# Altium schematic sheet Main.SchDoc
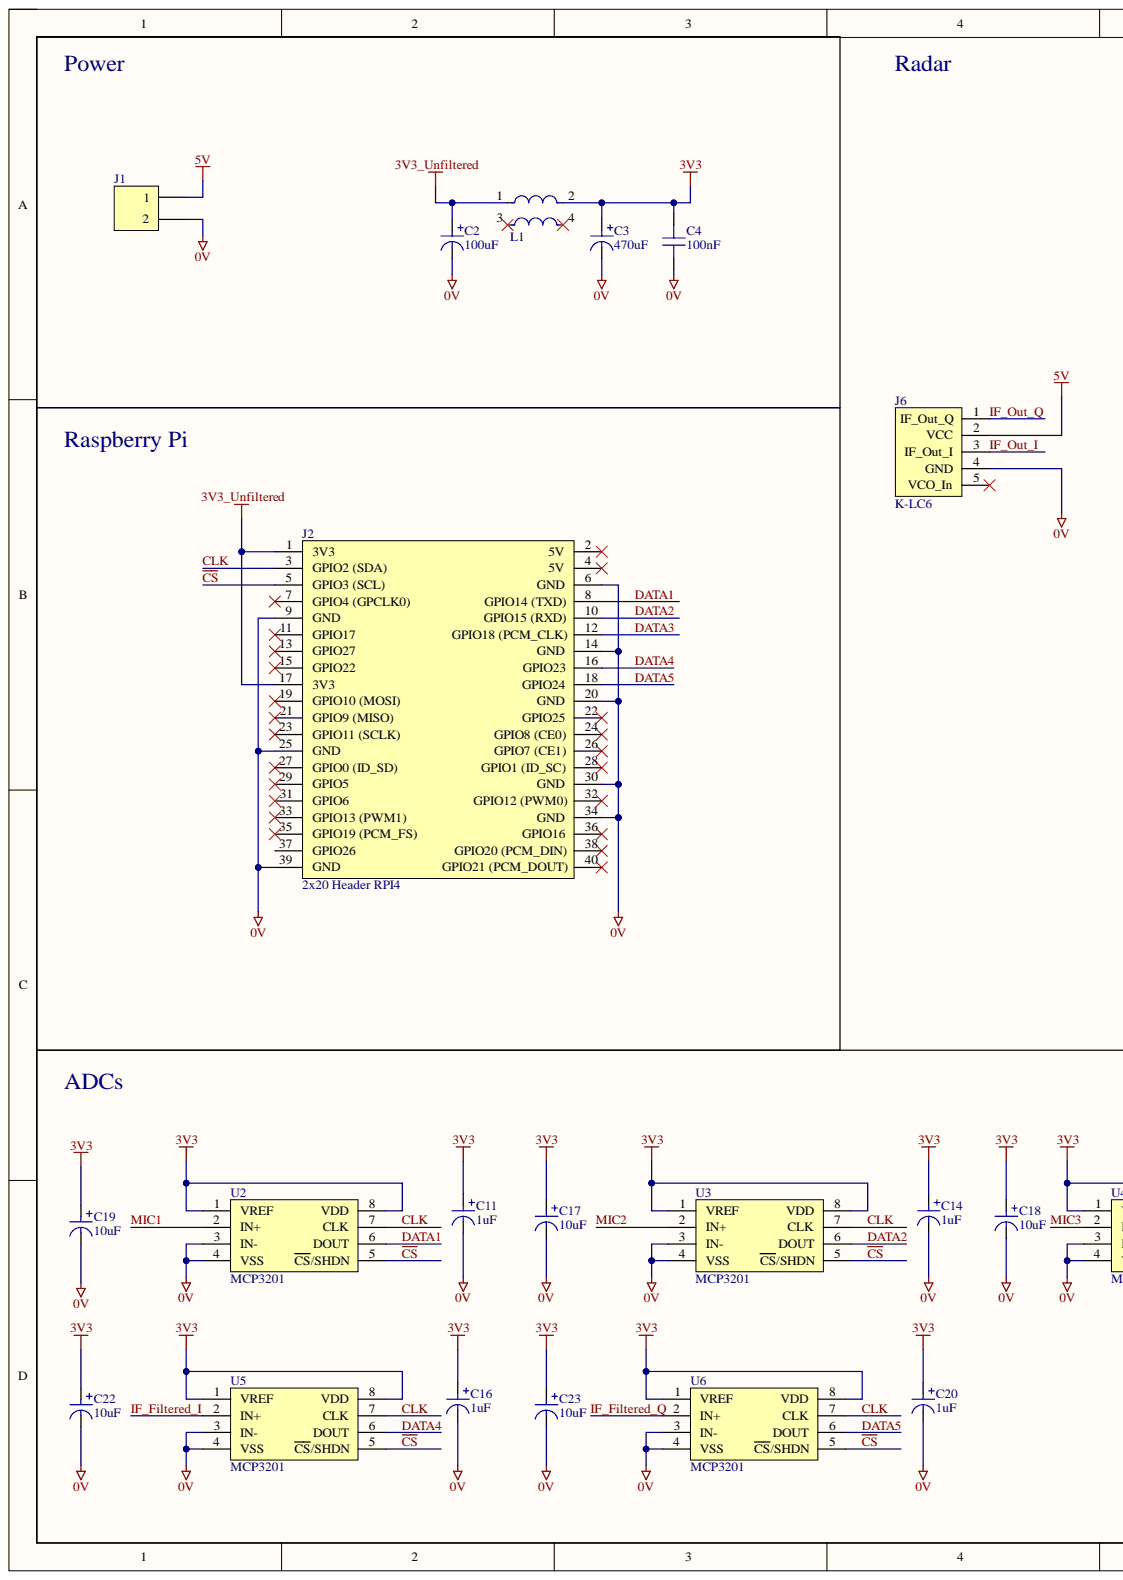
[diagram p0: Main.SchDoc, left]
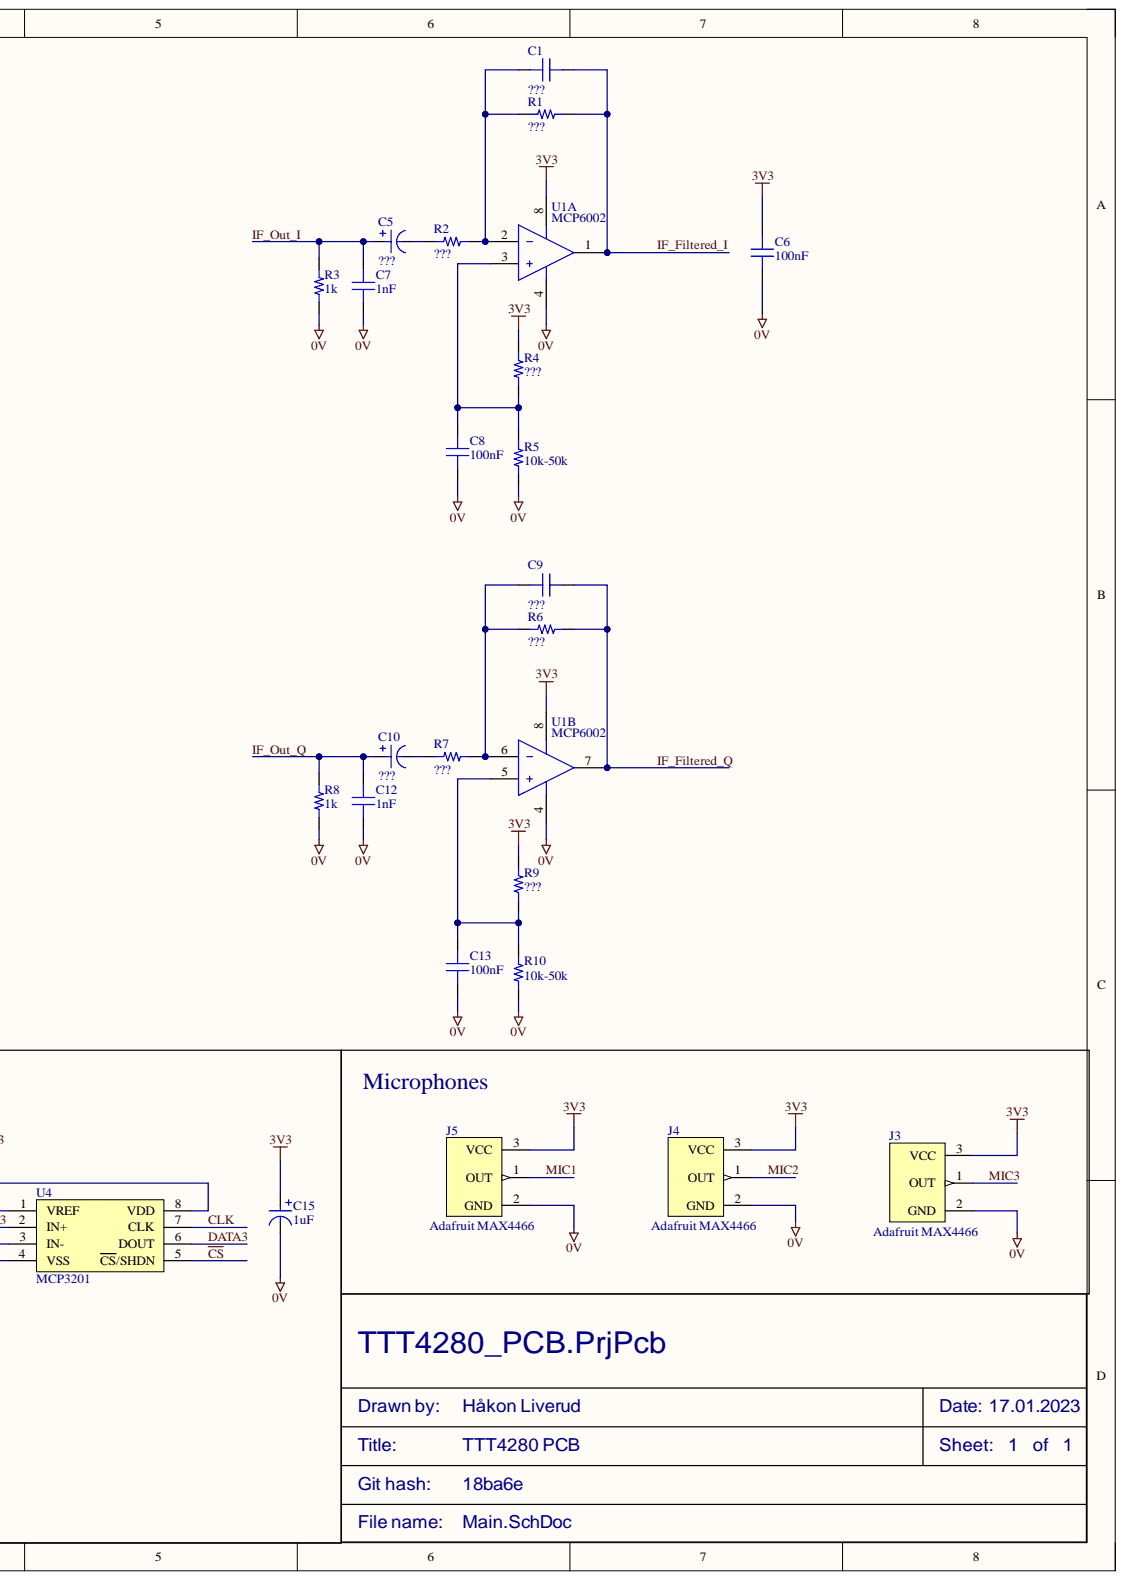
[diagram p1: Main.SchDoc, right]

## Parts
C1  ???  [CTHT Ceramic]
C2  100uF  [CTHT 5MM]
C3  470uF  [CTHT 8mm]
C4  100nF  [C TDK Film 7.3x2.5x6.5mm]
C5  ???  [CTHT 5MM]
C6  100nF  [C TDK Film 7.3x2.5x6.5mm]
C7  1nF  [CTHT Ceramic]
C8  100nF  [C TDK Film 7.3x2.5x6.5mm]
C9  ???  [CTHT Ceramic]
C10  ???  [CTHT 5MM]
C11  1uF  [CTHT 4MM]
C12  1nF  [CTHT Ceramic]
C13  100nF  [C TDK Film 7.3x2.5x6.5mm]
C14  1uF  [CTHT 4MM]
C15  1uF  [CTHT 4MM]
C16  1uF  [CTHT 4MM]
C17  10uF  [CTHT 4MM]
C18  10uF  [CTHT 4MM]
C19  10uF  [CTHT 4MM]
C20  1uF  [CTHT 4MM]
C22  10uF  [CTHT 4MM]
C23  10uF  [CTHT 4MM]
J1  Header 2  [HDR1X2]  (value not drawn)
J2  2x20 Header RPI4  [HDRV40W87P254_2X20_5093X508X1067P]
J3  Adafruit MAX4466  [MODULE_1063]
J4  Adafruit MAX4466  [MODULE_1063]
J5  Adafruit MAX4466  [MODULE_1063]
J6  K-LC6  [K-LC6]
L1  100mH Inductor  [DKFP-G22G-D5J0]  (value not drawn)
R1  ???  [RTHT]
R2  ???  [RTHT]
R3  1k  [RTHT]
R4  ???  [RTHT]
R5  10k-50k  [RTHT]
R6  ???  [RTHT]
R7  ???  [RTHT]
R8  1k  [RTHT]
R9  ???  [RTHT]
R10  10k-50k  [RTHT]
U1  MCP6002  [DIP781W56P254L950H533Q8N]  (parts A,B)
U2  MCP3201  [DIP781W56P254L950H533Q8N]
U3  MCP3201  [DIP781W56P254L950H533Q8N]
U4  MCP3201  [DIP781W56P254L950H533Q8N]
U5  MCP3201  [DIP781W56P254L950H533Q8N]
U6  MCP3201  [DIP781W56P254L950H533Q8N]

## Nets
0V: C2.2 C3.2 C4.1 C6.1 C7.1 C8.1 C11.2 C12.1 C13.1 C14.2 C15.2 C16.2 C17.2 C18.2 C19.2 C20.2 C22.2 C23.2 J1.2 J2.6(GND) J2.9(GND) J2.14(GND) J2.20(GND) J2.25(GND) J2.30(GND) J2.34(GND) J2.39(GND) J3.2 J4.2 J5.2 J6.4(GND) R3.1 R5.1 R8.1 R10.1 U1.4(0V) U2.3(IN-) U2.4(VSS) U3.3(IN-) U3.4(VSS) U4.3(IN-) U4.4(VSS) U5.3(IN-) U5.4(VSS) U6.3(IN-) U6.4(VSS)
3V3: C3.1 C4.2 C6.2 C11.1 C14.1 C15.1 C16.1 C17.1 C18.1 C19.1 C20.1 C22.1 C23.1 J3.3 J4.3 J5.3 L1.2 R4.2 R9.2 U1.8 U2.1(VREF) U2.8(VDD) U3.1(VREF) U3.8(VDD) U4.1(VREF) U4.8(VDD) U5.1(VREF) U5.8(VDD) U6.1(VREF) U6.8(VDD)
3V3_Unfiltered: C2.1 J2.1(3V3) J2.17(3V3) L1.1
5V: J1.1 J6.2(VCC)
~{CS}: J2.5(GPIO3_(SCL)) U2.5(CS/SHDN) U3.5(CS/SHDN) U4.5(CS/SHDN) U5.5(CS/SHDN) U6.5(CS/SHDN)
CLK: J2.3(GPIO2_(SDA)) U2.7(CLK) U3.7(CLK) U4.7(CLK) U5.7(CLK) U6.7(CLK)
DATA1: J2.8(GPIO14_(TXD)) U2.6(DOUT)
DATA2: J2.10(GPIO15_(RXD)) U3.6(DOUT)
DATA3: J2.12(GPIO18_(PCM_CLK)) U4.6(DOUT)
DATA4: J2.16(GPIO23) U5.6(DOUT)
DATA5: J2.18(GPIO24) U6.6(DOUT)
IF_Filtered_I: C1.2 R1.1 U1.1 U5.2(IN+)
IF_Filtered_Q: C9.2 R6.1 U1.7 U6.2(IN+)
IF_Out_I: C5.1 C7.2 J6.3(IF_Out_I) R3.2
IF_Out_Q: C10.1 C12.2 J6.1(IF_Out_Q) R8.2
MIC1: J5.1 U2.2(IN+)
MIC2: J4.1 U3.2(IN+)
MIC3: J3.1 U4.2(IN+)
NetC1_1: C1.1 R1.2 R2.1 U1.2
NetC5_2: C5.2 R2.2
NetC8_2: C8.2 R4.1 R5.2 U1.3
NetC9_1: C9.1 R6.2 R7.1 U1.6
NetC10_2: C10.2 R7.2
NetC13_2: C13.2 R9.1 R10.2 U1.5

Not connected: J2 2(5V) 4(5V) 7(GPIO4_(GPCLK0)) 11(GPIO17) 13(GPIO27) 15(GPIO22) 19(GPIO10_(MOSI)) 21(GPIO9_(MISO)) 22(GPIO25) 23(GPIO11_(SCLK)) 24(GPIO8_(CE0)) 26(GPIO7_(CE1)) 27(GPIO0_(ID_SD)) 28(GPIO1_(ID_SC)) 29(GPIO5) 31(GPIO6) 32(GPIO12_(PWM0)) 33(GPIO13_(PWM1)) 35(GPIO19_(PCM_FS)) 36(GPIO16) 37(GPIO26) 38(GPIO20_(PCM_DIN)) 40(GPIO21_(PCM_DOUT)); J6 5(VCO_In); L1 3 4

## Library data of the parts
C1 C7 C9 C12 = 1nF Ceramic: 1nF Ceramic Cap; Component Kind: Standard; Value: 1nF; Library Name: Altium-Priv.IntLib
C2 C5 C10 = 100uF 5mm THT: 100uF 5mm THT Cap; Component Kind: Standard; Library Name: Altium-Priv.IntLib
C3 = 470uF 8mm THT: 0.8pF 8mm THT Cap; Component Kind: Standard; Library Name: Altium-Priv.IntLib
C4 C6 C8 C13 = 100nF Film: 100nF Film Cap; Value: 0.1uF; Component Kind: Standard; Library Name: Altium-Priv.IntLib
C11 C14-C16 C20 = 1uF 4mm THT: 1uF 4mm THT Cap; Component Kind: Standard; Library Name: Altium-Priv.IntLib
C17-C19 C22 C23 = 10uF 4mm THT: 10uF 4mm THT Cap; Component Kind: Standard; Library Name: Altium-Priv.IntLib
J1 = Header 2: Header, 2-Pin; Component Kind: Standard; LatestRevisionDate: 17-Jul-2002; LatestRevisionNote: Re-released for DXP Platform.; Library Name: Altium-Priv.IntLib; Publisher: Altium Limited
J2 = 2222_1: GPIO MALE HEADER RASPBERRY PI; Component Kind: Standard; Library Name: Altium-Priv.IntLib
J3-J5 = 1063: Microphone Pre-Amp Audio Evaluation Board; MF: {'manufacturer': u'Adafruit'}; Availability: Unavailable; Component Kind: Standard; MP: 1063; Package: None; Price: None; Library Name: Altium-Priv.IntLib
J6 = K-LC6: Radar Transceiver Module 24.05GHz ~ 24.25GHz Integrated, Ceramic Patch Through Hole; Component Kind: Standard; LatestRevisionDate: 17-Jul-2002; LatestRevisionNote: Re-released for DXP Platform.; Library Name: Altium-Priv.IntLib; Publisher: Altium Limited
L1 = DKFP-G22G-D5J0: Common Mode Chokes / Filters DKFP COMP.CHOKE THT P O 0.5A 2X100mH; Component Kind: Standard; Library Name: Altium-Priv.IntLib
R1-R10 = 1k THT: 1k THT Res; Component Kind: Standard; Value: 1K; Library Name: Altium-Priv.IntLib
U1 = MCP6022-P-8: Operational Amplifiers - Op Amps Dual 1.8V 1MHz; Component Kind: Standard; Library Name: Altium-Priv.IntLib
U2-U6 = MCP3201-BI_P: 12-Bit, 100kSPS, Single Channel ADC; Manufacturer_Name: Microchip; Manufacturer_Part_Number: MCP3201-BI/P; Arrow Part Number: MCP3201-BI/P; Arrow Price/Stock: https://www.arrow.com/en/products/mcp3201-bip/microchip-technology?region=nac; Component Kind: Standard; Datasheet Link: http://uk.rs-online.com/web/p/products/1654833; Height: 5.334mm; Mouser Part Number: 579-MCP3201-BI/P; Mouser Price/Stock: https://www.mouser.co.uk/ProductDetail/Microchip-Technology-Atmel/MCP3201-BI-P?qs=Vn0zuzr35GGoo291EIbsHA%3D%3D; Library Name: Altium-Priv.IntLib
